# Altium schematic sheet SingleSwitch.SchDoc
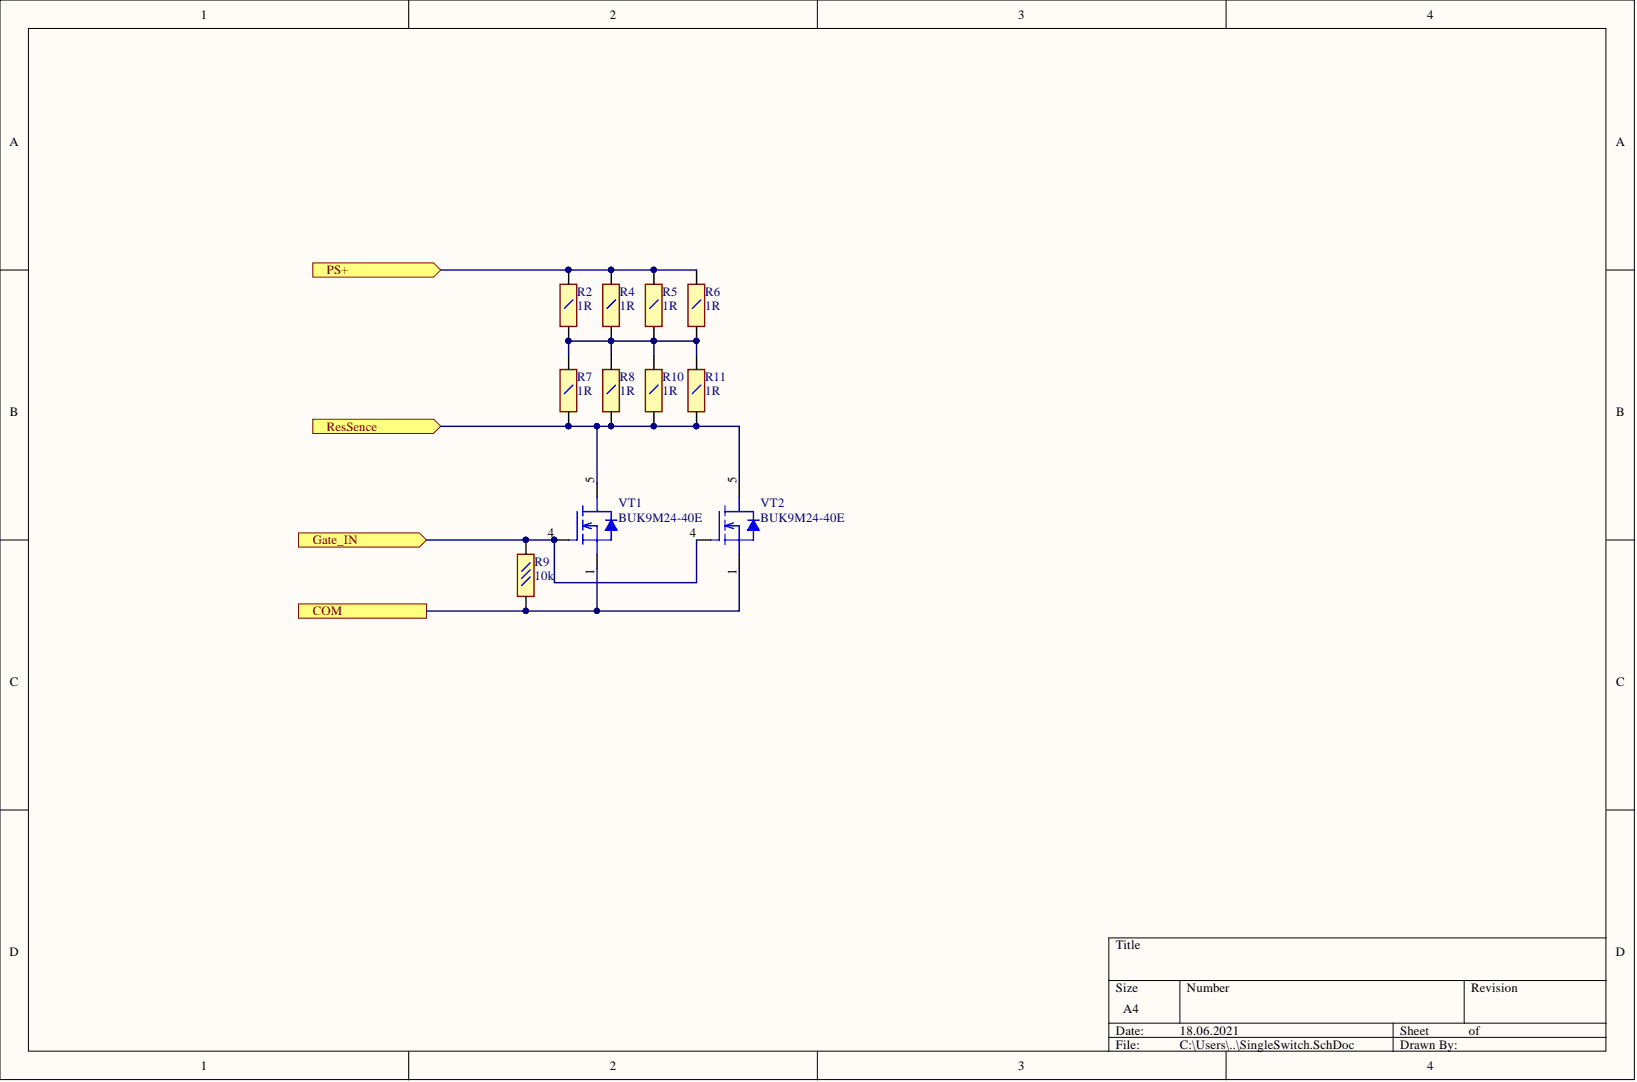
[diagram p0: SingleSwitch.SchDoc]

## Parts
R2  1R  [1206r]
R4  1R  [1206r]
R5  1R  [1206r]
R6  1R  [1206r]
R7  1R  [1206r]
R8  1R  [1206r]
R9  10k  [0603r]
R10  1R  [1206r]
R11  1R  [1206r]
VT1  BUK9M24-40E  [LFPAK33]
VT2  BUK9M24-40E  [LFPAK33]

## Ports
COM, Gate_IN, PS+, ResSence

## Nets
COM: R9.2 VT1.1 VT2.1 ; port COM
Gate_IN: R9.1 VT1.4 VT2.4 ; port Gate_IN
PS+: R2.1 R4.1 R5.1 R6.1 ; port PS+
ResSence: R7.2 R8.2 R10.2 R11.2 VT1.5 VT2.5 ; port ResSence
NetR2_2: R2.2 R4.2 R5.2 R6.2 R7.1 R8.1 R10.1 R11.1

## Library data of the parts
R2 R4-R8 R10 R11 = 1R 1206 1%: Company: Uni-Ohm; Order Code: 1206S4F0100T50; Component Kind: Standard; Description: 1R 1206 1%; Type: Resistor; Value: 1R; Library Name: RESISTORs_Home.SchLib
R9 = 10k 0603 5%: Company: Yageo; ID: B112CV1113Y; Order Code: RC0603JR-0710KL; TSKD: FS-CM_StandDet_Resistor_SMD(10K_5%_100_0603); Component Kind: Standard; Description: 10k 0603 5%; Library Name: RESISTORs_Home.SchLib; Supplier 1: Digi-Key; Supplier Part Number 1: 311-10KGRCT-ND; Type: Resistor; Value: 10k
VT1 VT2 = BUK9M24-40E: N-channel 40 V, 24 mΩ logic level MOSFET in LFPAK33; Company: Nexperia; Order Code: BUK9M24-40EX; Type: Transistor; Component Kind: Standard; ComponentLink1Description: PDF; ComponentLink1URL: https://datasheet.octopart.com/BUK9M24-40EX-Nexperia-datasheet-82522595.pdf; Mounting: SMD; Library Name: TRANZ_Library.SchLib
